# Altium schematic sheet FEZ-Duino-Connectors.SchDoc(FEZ-Duino-Connectors)
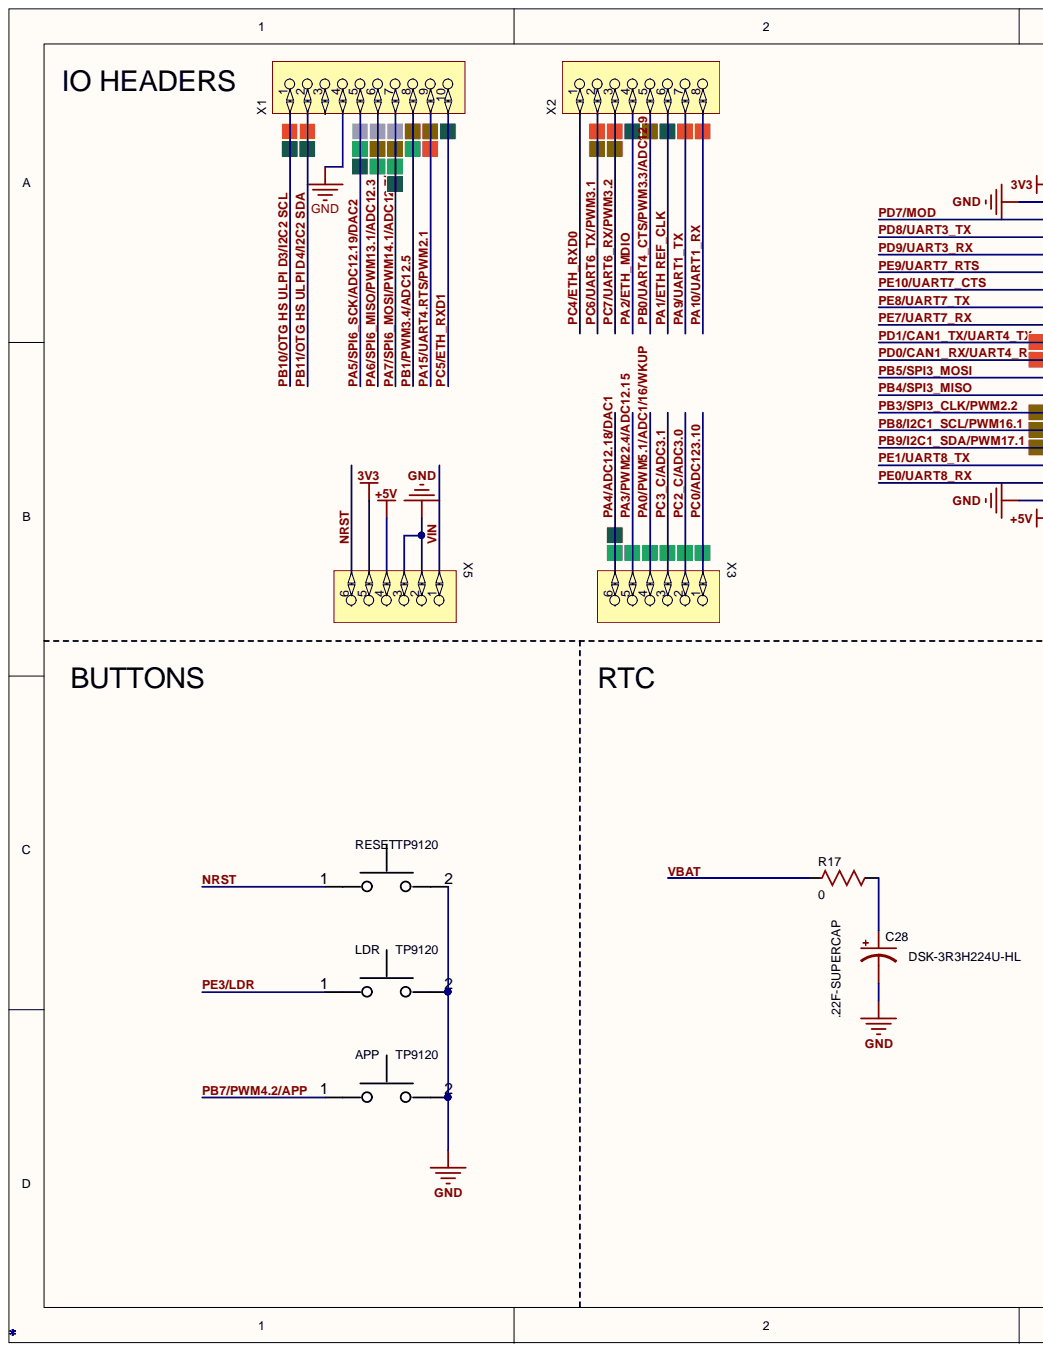
[diagram p0: FEZ-Duino-Connectors.SchDoc, left]
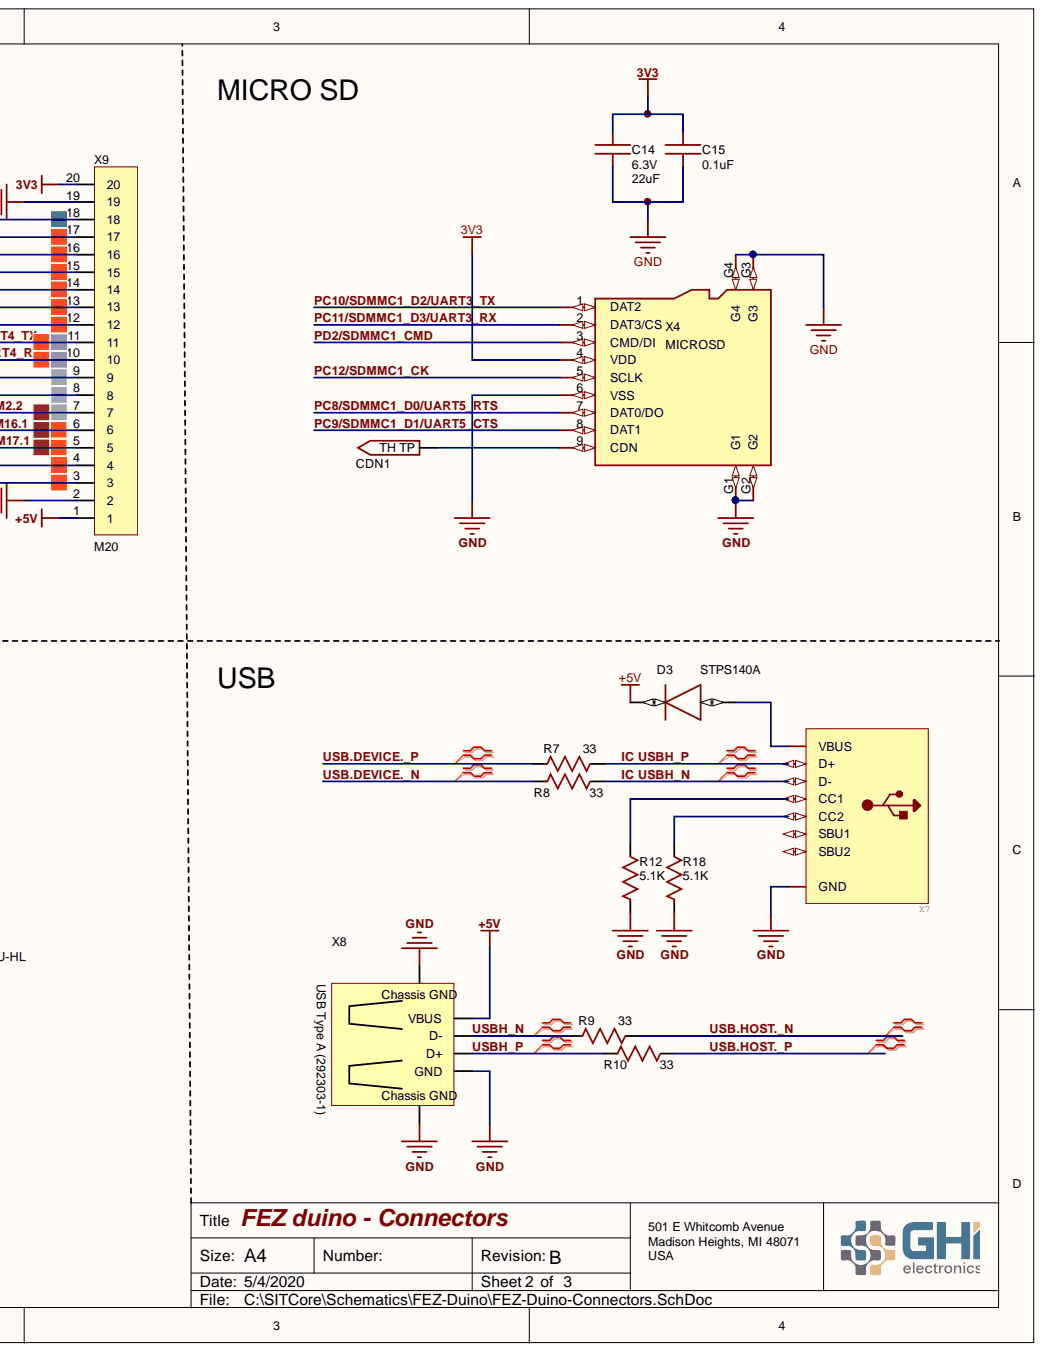
[diagram p1: FEZ-Duino-Connectors.SchDoc, right]

## Parts
APP  value TP9120  [Button - TL9210]  (hidden comment: Button - TP9120)
C14  value 22uF  Voltage: 6.3V  [C0805 L]  (hidden comment: 0805 Cap 22uF)
C15  value 0.1uF  [C0603 (1608 Metric)]  (hidden comment: 0603 Cap 0.1uF)
C28  .22F-SUPERCAP  value DSK-3R3H224U-HL  [DSK-RADIAL-6.8MM]
CDN1  TH Testpad 32mil (32mil ar)  [32mil TH]  (value not drawn)  (no BOM)
D3  STPS140A  [DIODE_SMA]
LDR  value TP9120  [Button - TL9210]  (hidden comment: Button - TP9120)
R7  value 33  [R0603 (1608 Metric)]  (hidden comment: 0603 33)
R8  value 33  [R0603 (1608 Metric)]  (hidden comment: 0603 33)
R9  value 33  [R0603 (1608 Metric)]  (hidden comment: 0603 33)
R10  value 33  [R0603 (1608 Metric)]  (hidden comment: 0603 33)
R12  value 5.1K  [R0402]  (hidden comment: 0402 Res 5.1K)
R17  value 0  [R0603 (1608 Metric)]  (hidden comment: 0603 Res 0)
R18  value 5.1K  [R0402]  (hidden comment: 0402 Res 5.1K)
RESET  value TP9120  [Button - TL9210]  (hidden comment: Button - TP9120)
X1  1X10-(INCH)-0.1-SMT  [SMT-1X10]  (value not drawn)
X2  1X8-(INCH)-0.1-SMT  [SMT-1X8]  (value not drawn)
X3  1X6-(INCH)-0.1-SMT  [SMT-1X6]  (value not drawn)
X4  MICROSD  [MICROSD]
X5  1X6-(INCH)-0.1-SMT  [SMT-1X6]  (value not drawn)
X7  (no value)  [GCT_USB4105_revA]
X8  USB Type A (292303-1)  [AMP 292303-1]
X9  M20  [1X20]

## Nets
3V3: C14.2 C15.2 X4.4(VDD) X5.5 X9.20
+5V: D3.K X5.4 X8.1(VBUS) X9.1
GND: APP.2 C14.1 C15.1 C28.- LDR.2 R12.1 R18.1 RESET.2 X1.4 X4.6(VSS) X4.G1 X4.G2 X4.G3 X4.G4 X5.2 X5.3 X7.GND X8.4(GND) X8.CG1 X8.CG2 X9.2 X9.19
IC USBH_N: R8.2 X7.A7B7(D-)
IC USBH_P: R7.2 X7.A6B6(D+)
NRST: RESET.1 X5.6
PA0/PWM5.1/ADC1/16/WKUP: X3.4
PA1/ETH REF_CLK: X2.6
PA2/ETH_MDIO: X2.4
PA3/PWM22.4/ADC12.15: X3.5
PA4/ADC12.18/DAC1: X3.6
PA5/SPI6_SCK/ADC12.19/DAC2: X1.5
PA6/SPI6_MISO/PWM13.1/ADC12.3: X1.6
PA7/SPI6_MOSI/PWM14.1/ADC12.7/ETH_DV: X1.7
PA9/UART1_TX: X2.7
PA10/UART1_RX: X2.8
PA15/UART4.RTS/PWM2.1: X1.9
PB0/UART4_CTS/PWM3.3/ADC12.9: X2.5
PB1/PWM3.4/ADC12.5: X1.8
PB3/SPI3_CLK/PWM2.2: X9.7
PB4/SPI3_MISO: X9.8
PB5/SPI3_MOSI: X9.9
PB7/PWM4.2/APP: APP.1
PB8/I2C1_SCL/PWM16.1: X9.6
PB9/I2C1_SDA/PWM17.1: X9.5
PB10/OTG HS ULPI D3/I2C2 SCL: X1.1
PB11/OTG HS ULPI D4/I2C2 SDA: X1.2
PC0/ADC123.10: X3.1
PC2_C/ADC3.0: X3.2
PC3_C/ADC3.1: X3.3
PC4/ETH_RXD0: X2.1
PC5/ETH_RXD1: X1.10
PC6/UART6_TX/PWM3.1: X2.2
PC7/UART6_RX/PWM3.2: X2.3
PC8/SDMMC1_D0/UART5_RTS: X4.7(DAT0/DO)
PC9/SDMMC1_D1/UART5_CTS: X4.8(DAT1)
PC10/SDMMC1_D2/UART3_TX: X4.1(DAT2)
PC11/SDMMC1_D3/UART3_RX: X4.2(DAT3/CS)
PC12/SDMMC1_CK: X4.5(SCLK)
PD0/CAN1_RX/UART4_RX: X9.10
PD1/CAN1_TX/UART4_TX: X9.11
PD2/SDMMC1_CMD: X4.3(CMD/DI)
PD7/MOD: X9.18
PD8/UART3_TX: X9.17
PD9/UART3_RX: X9.16
PE0/UART8_RX: X9.3
PE1/UART8_TX: X9.4
PE3/LDR: LDR.1
PE7/UART7_RX: X9.12
PE8/UART7_TX: X9.13
PE9/UART7_RTS: X9.15
PE10/UART7_CTS: X9.14
USB.DEVICE._N: R8.1
USB.DEVICE._P: R7.1
USB.HOST._N: R9.2
USB.HOST._P: R10.2
USBH_N: R9.1 X8.2(D-)
USBH_P: R10.1 X8.3(D+)
VBAT: R17.1
VIN: X5.1
NetC28_+: C28.+ R17.2
NetCDN1_1: CDN1.1 X4.9(CDN)
NetD3_A: D3.A X7.A4B9(VBUS)
NetR12_2: R12.2 X7.A5(CC1)
NetR18_2: R18.2 X7.B5(CC2)

Not connected: X1 3; X7 A8(SBU1) B8(SBU2)

## Library data of the parts
C14 = 0805 Cap 22uF: 22uF ±20% 6.3V X5R 0805 Multilayer Ceramic Capacitors MLCC - SMD/SMT RoHS; Mfr.Part #: CL21A226MQCLRNC; Tolerance: ±20%; Package: 0805; LCSC Part #: C307530; Component Kind: Standard; Category: Ceramic; Capacitance: 22uF; Library Name: GHI-Passives.SchLib
C15 = 0603 Cap 0.1uF: 0.1uF ±5% 50V X7R 0603 Multilayer Ceramic Capacitors MLCC - SMD/SMT RoHS; Mfr.Part #: CL10B104JB8NNNC; Voltage: 50V; Tolerance: ±5%; Package: 0603; LCSC Part #: C24452; Digikey: 1276-1033-1-ND; Component Kind: Standard; Category: Ceramic; Capacitance: 0.1uF; Library Name: GHI-Passives.SchLib
C28 = .22F-SUPERCAP: ELNA DSK-621 - 220mF Electric Double Layer Capacitor (EDLC/SuperCapacitor)    A 220 mF SuperCapacitor. Used for backup power on devices with low standby current; Digikey: https://www.digikey.com/product-detail/en/elna-america/DSK-3R3H224U-HL/604-1020-2-ND/970181; Component Kind: Standard; Library Name: GHI.SchLib
CDN1 = TH Testpad 32mil (32mil ar): TH Testpad 32mil (32mil annular ring); Library Name: GHI.SchLib
D3 = DIODE_SMA: SymbolName_1: DIODE; GateName_1: G$1; Value: STPS140A; DeviceSetName: DIODE_SMA; Component Kind: Standard; LibraryName: GHI; Library Name: GHI.SchLib
R7-R10 = 0603 Res 33: 33 ±1% 1/10W ±100ppm/℃ 0603 Chip Resistor - Surface Mount RoHS; Mfr.Part #: RC0603FR-0733RL; Manufacturer: YAGEO; Voltage Rating: *V; Resistance Tolerance: ±1%; Resistance: 33; Power Rating: 1/10W; Package: 0603; LCSC Part #: C108661; Component Kind: Standard; Library Name: GHI-Passives.SchLib
R12 R18 = 0402 Res 5.1K: 5.1K ±1% 1/16W ±100ppm/℃ 0402 Chip Resistor - Surface Mount RoHS; Mfr.Part #: RC0402FR-075K1L; Manufacturer: YAGEO; Voltage Rating: *V; Resistance Tolerance: ±1%; Resistance: 5.1K; Power Rating: 1/16W; Package: 0402; LCSC Part #: C105872; Component Kind: Standard; Library Name: GHI-Passives.SchLib
R17 = 0603 Res 0: ±5% 1/10W 0603 Chip Resistor - Surface Mount RoHS; Mfr.Part #: RC0603JR-070RL; Manufacturer: YAGEO; Voltage Rating: *V; Resistance Tolerance: ±5%; Resistance: 0; Power Rating: 1/10W; Package: 0603; LCSC Part #: C95177; Component Kind: Standard; Library Name: GHI-Passives.SchLib
X1 = 1X10-(INCH)-0.1-SMT: SymbolName_1: PINHEAD-1X10; GateName_1: G$1; DeviceName: SMT; Value: 1X10-(INCH)-0.1-; Component Kind: Standard; LibraryName: GHI-Headers; Library Name: GHI.SchLib
X2 = 1X8-(INCH)-0.1-SMT: SymbolName_1: PINHEAD-1X8; GateName_1: G$1; DeviceName: SMT; Value: 1X8-(INCH)-0.1-; Component Kind: Standard; LibraryName: GHI-Headers; Library Name: GHI.SchLib
X3 X5 = 1X6-(INCH)-0.1-SMT: SymbolName_1: PINHEAD-1X6; GateName_1: G$1; DeviceName: SMT; Value: 1X6-(INCH)-0.1-; Component Kind: Standard; LibraryName: GHI-Headers; Library Name: GHI.SchLib
X4 = MICROSD: GateName_1: G$1; SymbolName_1: MICROSD; LibraryName: microSD; DeviceSetName: MICROSD; Component Kind: Standard; Library Name: GHI.SchLib
X7 = USB - C: USB - C (Type - C) USB 2.0 Receptacle Connector 24 (16+8 Dummy) Position Surface Mount, Right Angle; Through Hole; Manufacturer: GCT; Value: USB4105-GF-A; Mfr.Part #: USB4105-GF-A; LCSC Part #: C319148; Digi-Key Part Number: 2073-USB4105-GF-ATR-ND; Component Kind: Standard; : USB - C; Library Name: GHI-Connectors.SchLib
X8 = USB Type A Receptacle: TE Connectivity 292303-1 USB Type A receptacle; Value: 292303-1; PartNo: 292303-1; Component Kind: Standard; Library Name: GHI Sch.SchLib
X9 = M20: 1x20 .1" header<br> This is just like the LOCK variant in that the holes are staggared by 5 mil, but except for the last two holes.  They are not altered from the "standard" footprint layout.  This is used for a special purpose on the layout of our Graphic LCD Backpack.  Make sure you want to use this device before selecting it for you project!; Library Name: Connectors.SchLib; Component Kind: Standard
APP LDR RESET = Button - TP9120: PartNo: TP9120; Component Kind: Standard; Library Name: GHI.SchLib
